# Altium schematic sheet Power Supply and Connector.SchDoc
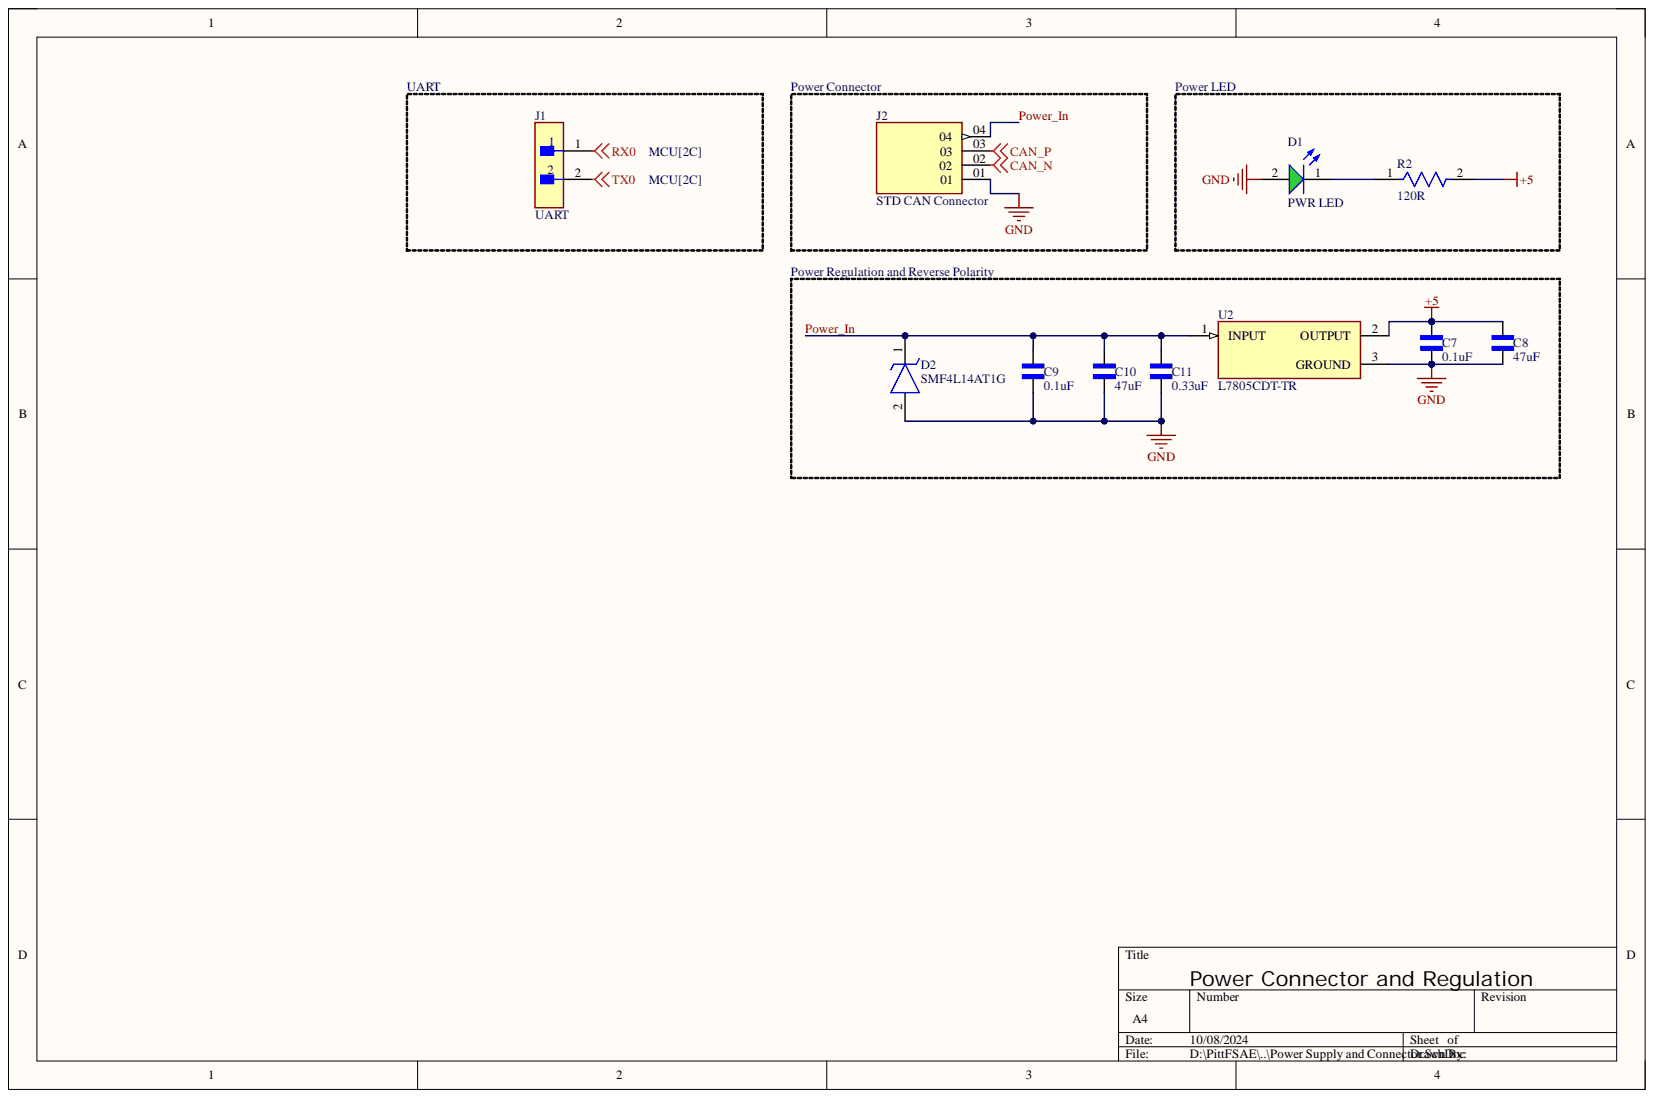
[diagram p0: Power Supply and Connector.SchDoc]

## Parts
C7  0.1uF  [CAPC2012X135N]
C8  47uF  [CAPC3216X160N]
C9  0.1uF  [CAPC2012X135N]
C10  47uF  [CAPC3216X160N]
C11  0.33uF  [CAPC2012X95N]
D1  PWR LED  [WL-SMCW_0805_150080xx75000]
D2  SMF4L14AT1G  [SODFL3619X98N]
J1  UART  [FP-TSW-102-07-F-S-MFG]
J2  STD CAN Connector  [SAMTEC_TSW-104-07-F-S]
R2  120R  [FP-CRCW0805-e3-IPC_C]
U2  L7805CDT-TR  [VREG_L7805CDT-TR]

## Nets
+5: C7.1 C8.2 R2.2 U2.2
CAN_N: J2.02
CAN_P: J2.03
GND: C7.2 C8.1 C9.2 C10.1 C11.1 D1.2 D2.2 J2.01 U2.3
RX0: J1.1
TX0: J1.2
Power_In: C9.1 C10.2 C11.2 D2.1 J2.04 U2.1
NetD1_1: D1.1 R2.1

## Library data of the parts
C7 C9 = GCM21BR71H104KA37L: CAP, MLCC, X7R, 0.1UF, 50V, 0805; MF: {'manufacturer': u'Murata'}; Availability: Unavailable; Component Kind: Standard; MP: GCM21BR71H104KA37L; Package: None; Price: None
C8 C10 = GRM31CR61E476ME44K: MF: {'manufacturer': u'Murata Electronics'}; Availability: Unavailable; Component Kind: Standard; MP: GRM31CR61E476ME44K; Package: None; Price: None
C11 = GCJ219R71H334KA12D: Cap Ceramic 0.33uF 50V X7R 10% SMD 0805 125Â°C Paper T/R; MF: {'manufacturer': u'Murata'}; Availability: Unavailable; Component Kind: Standard; MP: GCJ219R71H334KA12D; Package: None; Price: None
D1 = CMP-1426-00007-3: WURTH ELEKTRONIK - 150080GS75000 - LED, Green, SMD, 0805, 30 mA, 3.2 V, 520 nm; Dominant Wavelength: 525nm; Forward Current: 100mA; Forward Voltage: 3.2V; Lens Style: Clear, Rectangular; Luminous Intensity: 450mcd; Max Junction Temperature (Tj): 95°C; Max Reverse Voltage (DC): 5V; Peak Wavelength: 515nm; Power Dissipation: 105mW; Test Current: 20mA; Viewing Angle: 140°; Case Code (Imperial): 0805; Case Code (Metric): 2012; Case/Package: 0805; Color: Green; Component Kind: Standard; Height: 700µm; Length: 2mm; Library Name: Altium Content Vault; Max Operating Temperature: 85°C; Min Operating Temperature: -40°C; Mount: Surface Mount; Packaging: Tape and Reel; REACH SVHC: Unknown; Reverse Voltage: 5V; RoHS: Compliant; Wavelength: 525nm; Width: 1.25mm
D2 = SMF4L14AT1G: TVS Diode (Uni-directional); Manufacturer_Name: LITTELFUSE; Component Kind: Standard; Datasheet Link: https://www.littelfuse.com/media?resourcetype=datasheets&itemid=f093622a-2591-4e70-85e6-32321d2f8c5a&filename=tvs-diode-smf4l-t1g-datasheet; Height: 0.98mm; Manufacturer_Part_Number: SMF4L14AT1G; Mouser Part Number: 576-SMF4L14AT1G; Mouser Price/Stock: https://www.mouser.co.uk/ProductDetail/Littelfuse/SMF4L14AT1G?qs=OcgtsXO%252B3gvCCebw4hBNNg%3D%3D
J1 = CMP-02766-001458-1: CONN HEADER VERT 2POS 2.54MM; Gender: Male; Max Current Rating: 7.6A; Max Voltage Rating (AC): 550V; Number of Contacts: 2; Color: Black; Component Kind: Standard; Connector Type: Header; Contact Finish - Mating: GOLD; Contact Material: Bronze; Contact Mating Length: 0.23inch; Contact Plating: Gold; Contact Shape: Square; Contact Type: Male Pin; Depth: 2.54mm; Fastening Type: Pull; Flammability Rating: UL94 V-0; Housing Color: Black; Housing Material: Polyester; Insulation Color: Black; Insulation Material: PBT; Length: 5.08mm; Library Name: Altium Content Vault; Max Operating Temperature: 125°C; Min Operating Temperature: -55°C; Mount: Through Hole; Number of Positions: 2; Number of Rows: 1; Orientation: Straight; Package Quantity: 1; Packaging: Bulk; Pitch: 2.54mm; Radiation Hardening: No; REACH SVHC: No SVHC; RoHS Compliant: Yes; Series: TSW; Termination: Solder
J2 = TSW-104-07-F-S: MF: {'manufacturer': u'Samtec'}; Availability: Unavailable; Component Kind: Standard; MP: TSW-104-07-F-S; Package: None; Price: None
R2 = CMP-02407-007854-1: RES Thick Film, 120Ω, 1%, 0.125W, 100ppm/°C, 0805; Max Power Dissipation: 125mW; Voltage Rating: 150V; Case Code (Imperial): 0805; Case Code (Metric): 2012; Case/Package: 0805; Component Kind: Standard; Composition: Thick Film; Contact Plating: Tin; Depth: 1.25mm; Features: Automotive AEC-Q200; Height: 0.45mm; Lead Free: Lead Free; Length: 2mm; Library Name: Altium Content Vault; Max Operating Temperature: 155°C; Military Standard: Not; Min Operating Temperature: -55°C; Mount: Surface Mount; Number of Terminations: 2; Package Quantity: 10; Packaging: Tape and Reel; Power Rating: 125mW; Radiation Hardening: No; Resistance: 120R; Temperature Coefficient: 100ppm/°C; Termination: SMD/SMT; Tolerance: 1%; Voltage Rating (DC): 150V; Weight: 0.000194oz; Width: 1.25mm
U2 = L7805CDT-TR: L7805 Series 4.8 V 1.5 A Three Terminal Positive Voltage Regulator - TO-252-3; MF: {'manufacturer': u'STMicroelectronics'}; Availability: Unavailable; Component Kind: Standard; MP: L7805CDT-TR; Package: None; Price: None
